# Altium schematic sheet MIPI_DSI&LED&RTC.SchDoc(MIPI_DSI&LED&RTC)
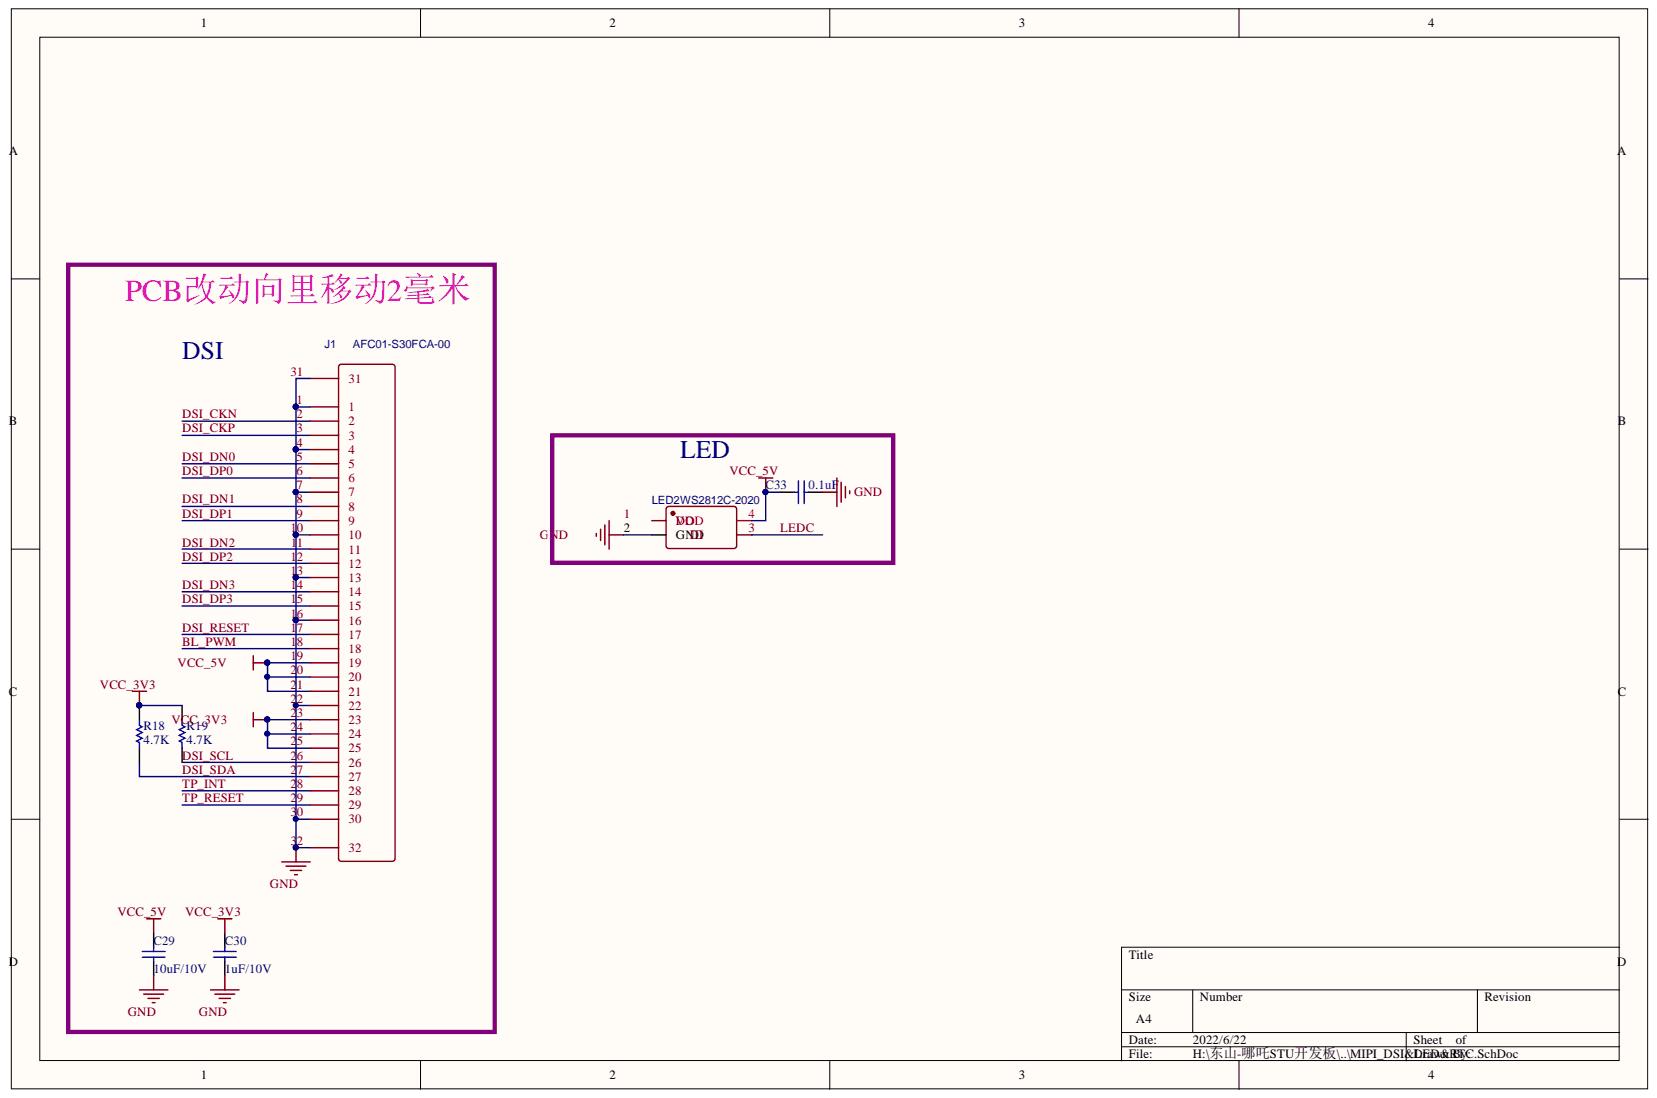
[diagram p0: MIPI_DSI&LED&RTC.SchDoc]

## Parts
C29  10uF/10V  [0402]
C30  1uF/10V  [0402]
C33  0.1uF  [0402]
J1  AFC01-S30FCA-00  [FPC-SMD_AFC01-S30FCA-00]
LED2  WS2812C-2020  [LED-SMD_L2.2-W2.0]
R18  4.7K  [0402]
R19  4.7K  [0402]

## Nets
GND: C29.1 C30.1 C33.1 J1.1 J1.4 J1.7 J1.10 J1.13 J1.16 J1.22 J1.30 J1.31 J1.32 LED2.2(GND)
VCC_3V3: C30.2 J1.23 J1.24 J1.25 R18.2 R19.2
VCC_5V: C29.2 C33.2 J1.19 J1.20 J1.21 LED2.4
BL_PWM: J1.18
DSI_CKN: J1.2
DSI_CKP: J1.3
DSI_DN0: J1.5
DSI_DN1: J1.8
DSI_DN2: J1.11
DSI_DN3: J1.14
DSI_DP0: J1.6
DSI_DP1: J1.9
DSI_DP2: J1.12
DSI_DP3: J1.15
DSI_RESET: J1.17
DSI_SCL: J1.26 R19.1
DSI_SDA: J1.27 R18.1
LEDC: LED2.3
TP_INT: J1.28
TP_RESET: J1.29

Not connected: LED2 1(VDD)

## Library data of the parts
C29 C30 C33 = Cap: Capacitor; PartNumber: CAP100NF; Component Kind: Standard; LatestRevisionDate: 17-Jul-2002; LatestRevisionNote: Re-released for DXP Platform.; Library Name: Miscellaneous Devices.IntLib; PackageReference: 0402; Published: 8-Jun-2000; Signal Integrity: Cap; Simulation: CAP; Publisher: Altium Limited
  C29: Value: 10uF/10V
  C30: Value: 1uF/10V
  C33: Value: 0.1uF
J1 = AFC01-S30FCA-00: BOM_Manufacturer: JUSHUO; Contributor: LCSC; BOM_JLCPCB Part Class: Extended Part; BOM_Manufacturer Part: AFC01-S30FCA-00; BOM_Supplier: LCSC; BOM_Supplier Part: C262671; Component Kind: Standard; spicePre: F; spiceSymbolName: AFC01-S30FCA-00
LED2 = WS2812C-2020: BOM_Manufacturer: worldsemi; Contributor: LCSC; BOM_JLCPCB Part Class: Extended Part; BOM_Manufacturer Part: WS2812C-2020; BOM_Supplier: LCSC; BOM_Supplier Part: C965556; Component Kind: Standard; spicePre: L; spiceSymbolName: WS2812C-2020
R18 R19 = Res1: Resistor; PartNumber: RES2.2K; Component Kind: Standard; LatestRevisionDate: 17-Jul-2002; LatestRevisionNote: Re-released for DXP Platform.; Library Name: Miscellaneous Devices.IntLib; PackageReference: 0402; Published: 8-Jun-2000; Signal Integrity: Res; Simulation: RESISTOR; Value: 4.7K; Publisher: Altium Limited
